# Altium schematic sheet zxmd.SchDoc
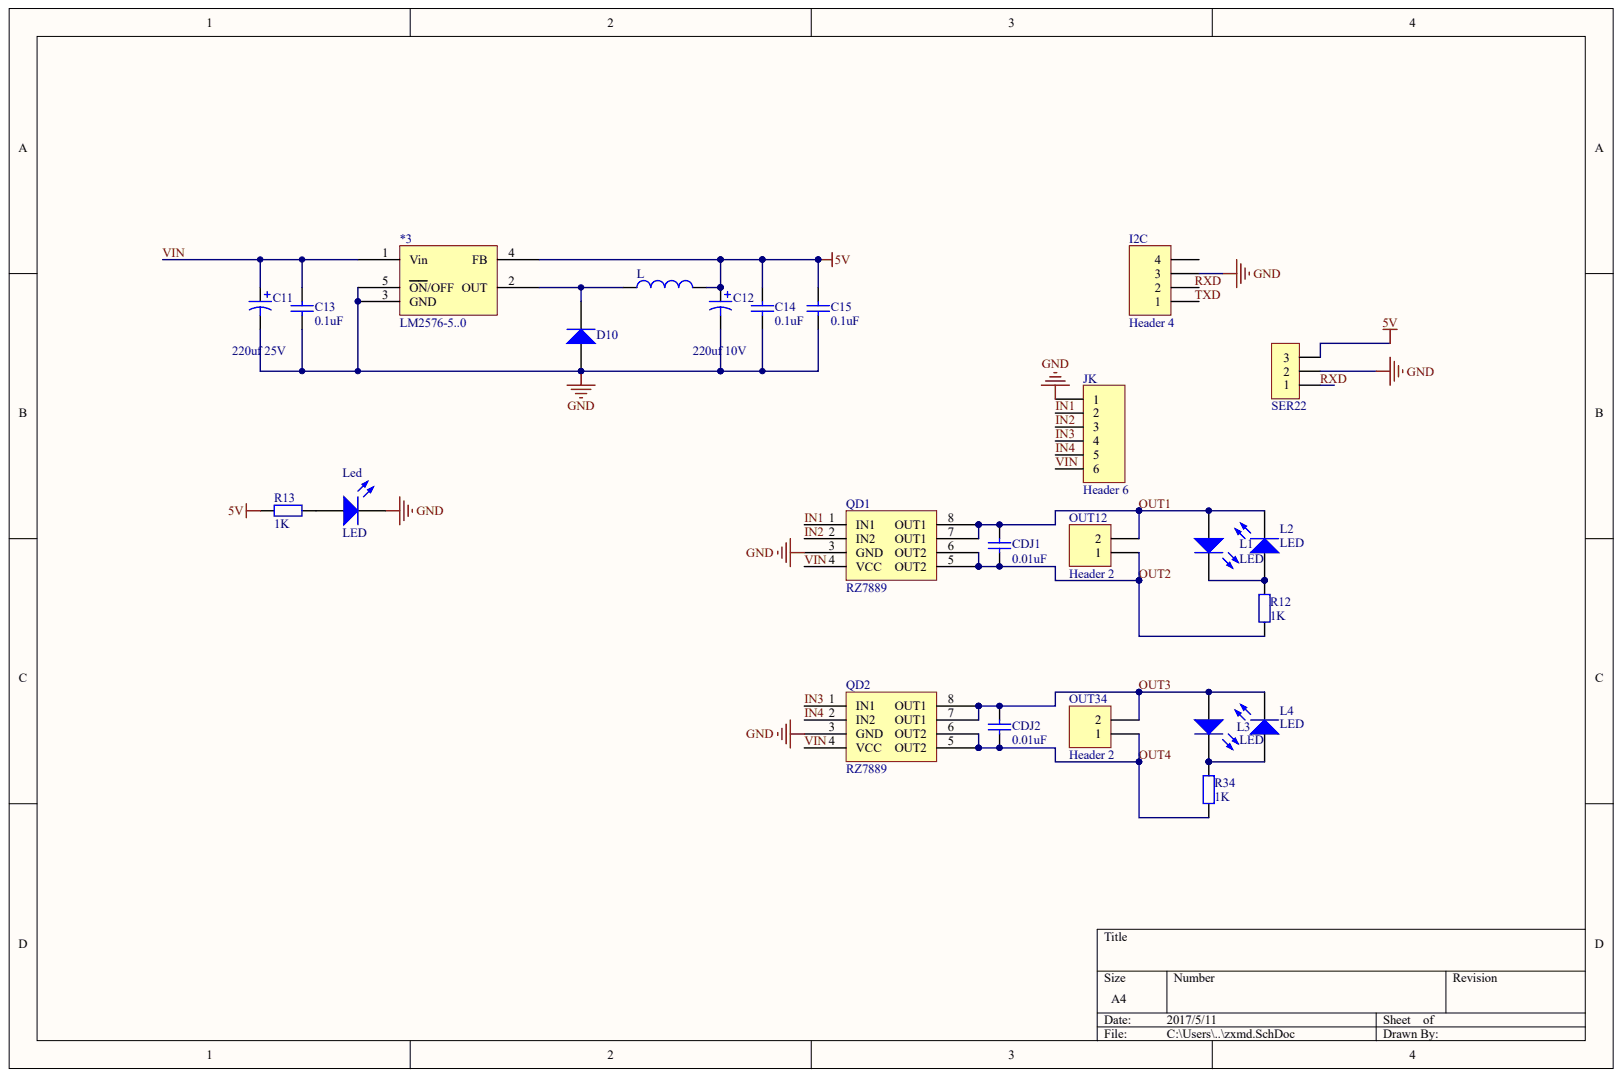
[diagram p0: zxmd.SchDoc]

## Parts
*3  LM2576-5..0
C11  220uf 25V
C12  220uf 10V
C13  value 0.1uF  (hidden comment: Cap)
C14  value 0.1uF  (hidden comment: Cap)
C15  value 0.1uF  (hidden comment: Cap)
CDJ1  value 0.01uF  (hidden comment: Cap)
CDJ2  value 0.01uF  (hidden comment: Cap)
D10  Diode 1N4007  (value not drawn)
I2C  Header 4
JK  Header 6
L  INDUCTOR  (value not drawn)
L1  LED
L2  LED
L3  LED
L4  LED
Led  LED
OUT12  Header 2
OUT34  Header 2
QD1  RZ7889
QD2  RZ7889
R12  value 1K  (hidden comment: Res2)
R13  value 1K  (hidden comment: Res2)
R34  value 1K  (hidden comment: Res2)
SER22  CON3  (value not drawn)

## Nets
5V: *3.4(FB) C12.1 C14.2 C15.2 L.2 R13.1 SER22.3
GND: *3.3(GND) *3.5(ON/OFF) C11.2 C12.2 C13.1 C14.1 C15.1 D10.1 I2C.3 JK.1 Led.2 QD1.3(GND) QD2.3(GND) SER22.2
IN1: JK.2 QD1.1(IN1)
IN2: JK.3 QD1.2(IN2)
IN3: JK.4 QD2.1(IN1)
IN4: JK.5 QD2.2(IN2)
OUT1: CDJ1.1 L1.1 L2.2 OUT12.2 QD1.7(OUT1) QD1.8(OUT1)
OUT2: CDJ1.2 OUT12.1 QD1.5(OUT2) QD1.6(OUT2) R12.1
OUT3: CDJ2.1 L3.1 L4.2 OUT34.2 QD2.7(OUT1) QD2.8(OUT1)
OUT4: CDJ2.2 OUT34.1 QD2.5(OUT2) QD2.6(OUT2) R34.1
RXD: SER22.1
VIN: *3.1(Vin) C11.1 C13.2 JK.6 QD1.4(VCC) QD2.4(VCC)
Net*3_2: *3.2(OUT) D10.2 L.1
NetL1_2: L1.2 L2.1 R12.2
NetL3_2: L3.2 L4.1 R34.2
NetLed_1: Led.1 R13.2
TXD:

Not connected: I2C 1 2 4

## Library data of the parts
*3 C11-C15 CDJ1 CDJ2 D10 I2C JK L L1-L4 Led OUT12 OUT34 QD1 QD2 R12 R13 R34 SER22 = (no symbol name)
  C13-C15 CDJ1 CDJ2: Published: 8-Jun-2000; LatestRevisionDate: 17-Jul-2002; LatestRevisionNote: Re-released for DXP Platform.; PackageReference: RAD-0.3
  D10: Published: 8-Jun-2000; LatestRevisionDate: 17-Jul-2002; LatestRevisionNote: Re-released for DXP Platform.; DatasheetDocument: 1998; PackageDocument: Aug-1999; PackageReference: DO-41; Code_JEDEC: DO-204-AL; ComponentLink1Description: Manufacturer Link; ComponentLink1URL: http://www.fairchildsemi.com/; ComponentLink2Description: Datasheet; ComponentLink2URL: http://www.fairchildsemi.com/ds/1N%2F1N4001.pdf
  I2C JK OUT12 OUT34: LatestRevisionDate: 17-Jul-2002; LatestRevisionNote: Re-released for DXP Platform.
  R12 R13 R34: Published: 8-Jun-2000; LatestRevisionDate: 17-Jul-2002; LatestRevisionNote: Re-released for DXP Platform.; PackageReference: AXIAL-0.4
  C13-C15 CDJ1 CDJ2 D10 I2C JK OUT12 OUT34 R12 R13 R34: Publisher: Altium Limited
